# Altium schematic sheet ADI COG Click Shield_v101_Semitron.SchDoc("ADI COG Click Shield_v101_Semitron")
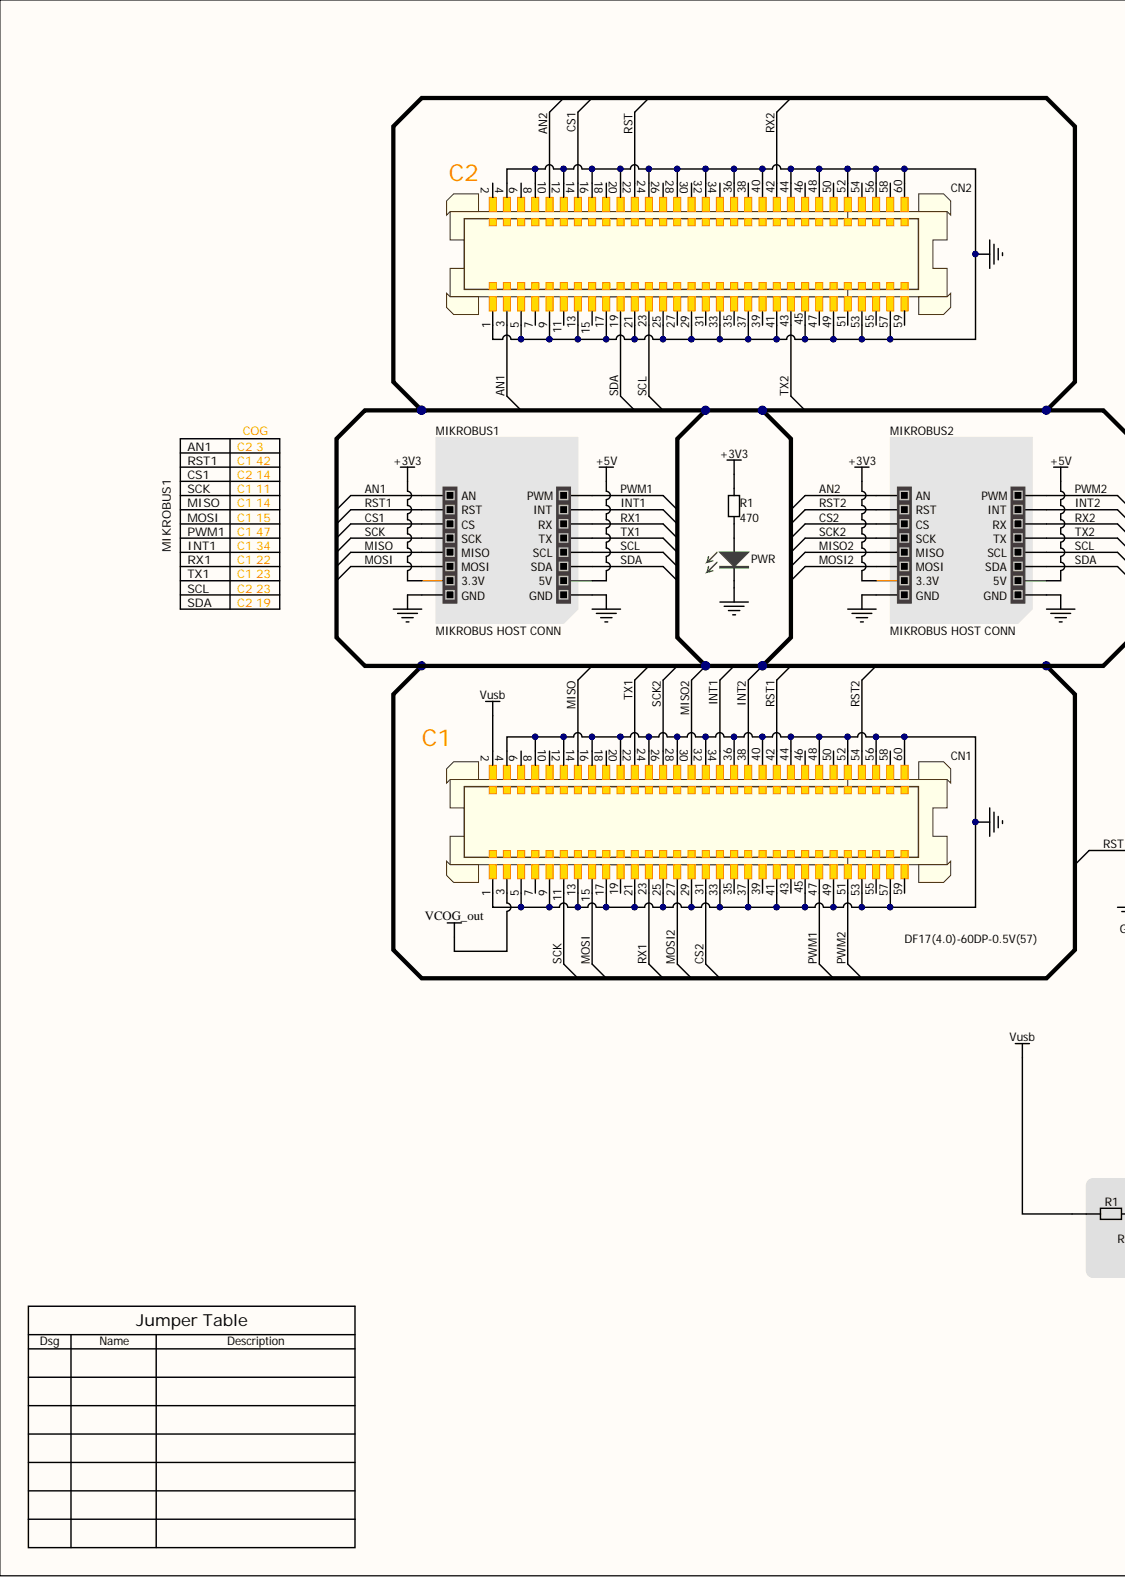
[diagram p0: ADI COG Click Shield_v101_Semitron.SchDoc, left]
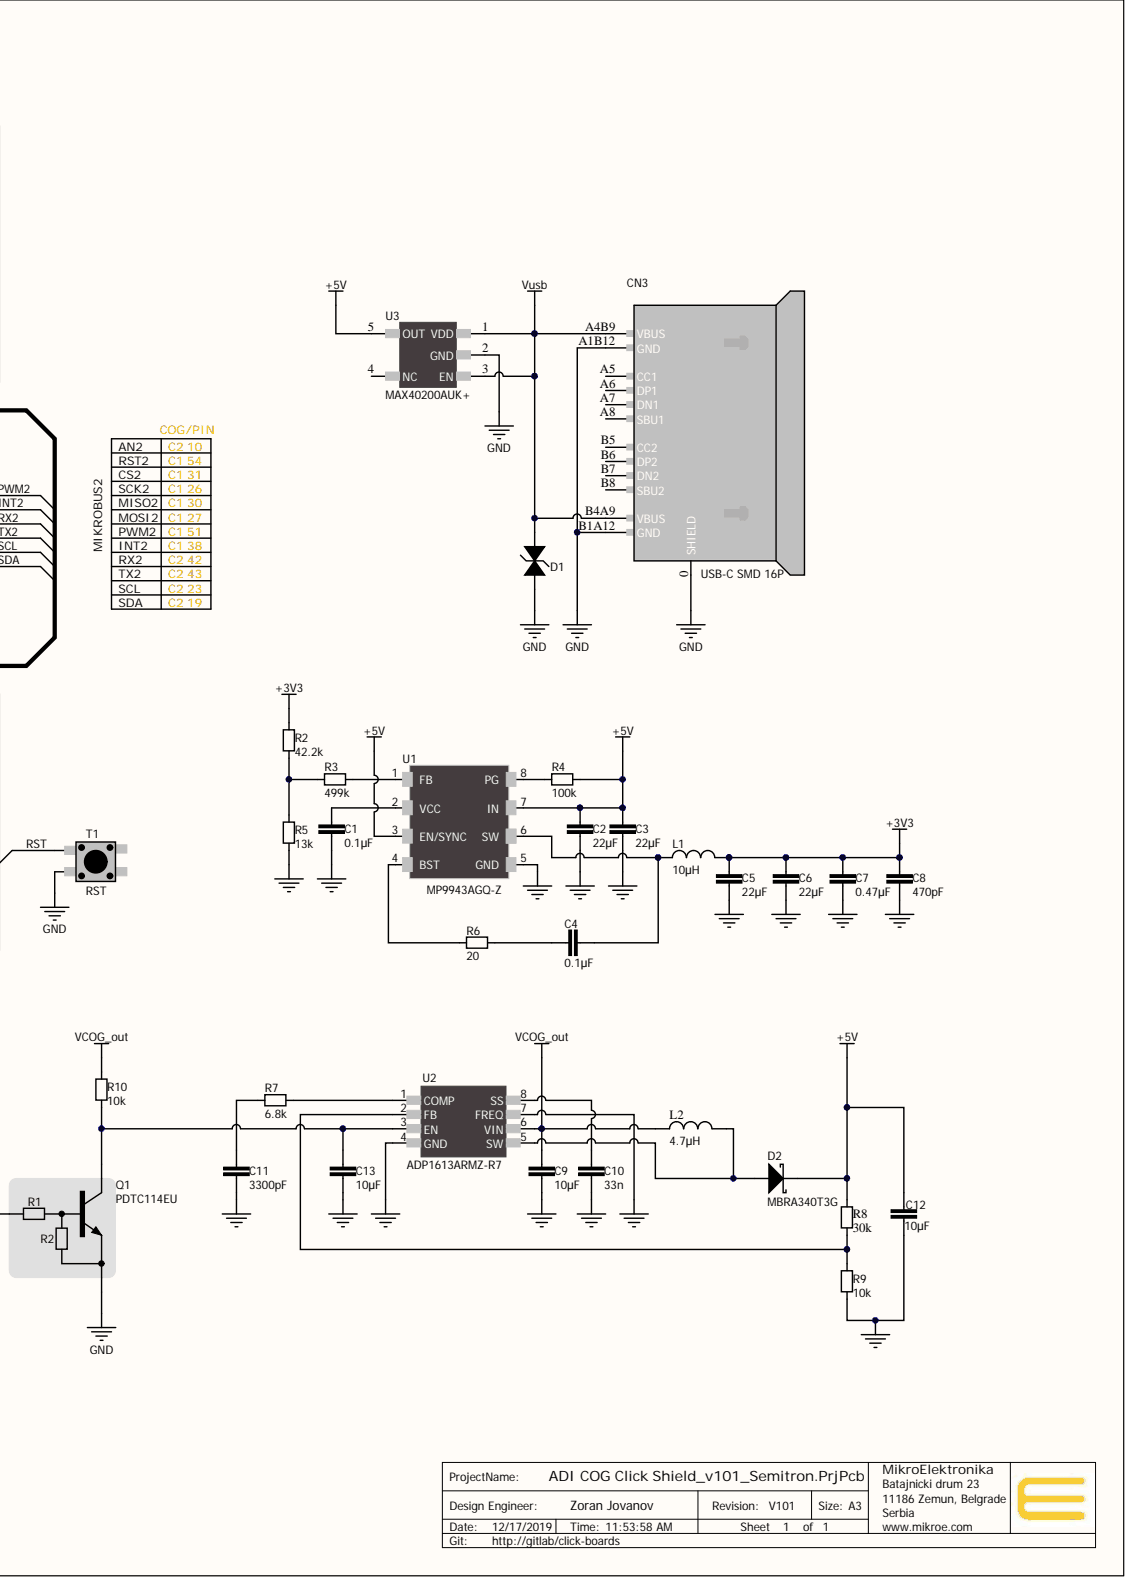
[diagram p1: ADI COG Click Shield_v101_Semitron.SchDoc, right]

## Parts
C1  0.1µF  [CAPC1005X55N-0402-NoOverlay]
C2  22µF  [CAPC2013X100N-0805-NoOverlay]
C3  22µF  [CAPC2013X100N-0805-NoOverlay]
C4  0.1µF  [CAPC1005X55N-0402-NoOverlay]
C5  22µF  [CAPC2013X100N-0805-NoOverlay]
C6  22µF  [CAPC2013X100N-0805-NoOverlay]
C7  0.47µF  [CAPC2013X100N-0805-NoOverlay]
C8  470pF  [CAPC2013X100N-0805-NoOverlay]
C9  10µF  [CAPC2013X100N-0805-NoOverlay]
C10  33n  [CAPC1608X55N-0603-NoOverlay]
C11  3300pF  [CAPC1608X55N-0603-NoOverlay]
C12  10µF  [CAPC2013X100N-0805-NoOverlay]
C13  10µF  [CAPC1608X55N-0603-NoOverlay]
CN1  DF17(4.0)-60DP-0.5V(57)  [PCBComponent_1]
CN2  DF17(4.0)-60DP-0.5V(57)  [PCBComponent_1]
CN3  USB-C SMD 16P  Assembly: SMD  [USB-C SMD 16P-NoOverlay]
D1  CPDUC5V0R-HF  [DIOC1508X55NP-0603-NoOverlay]  (value not drawn)
D2  MBRA340T3G  [DIODFN430X400X230-2N]
L1  10µH  [IND7.2X6.65X3-NoOverlay]
L2  4.7µH  [IND_HCM0703-NoOverlay]
LD1  PWR  [DIOGREENC2013X120N-0805-NoOverlay]
MIKROBUS1  MIKROBUS HOST CONN  [MIKROBUS HOST SMD 8GEN new V3]  (no BOM)
MIKROBUS2  MIKROBUS HOST CONN  [MIKROBUS HOST SMD 8GEN new V3]  (no BOM)
Q1  PDTC114EU  [SOT65P210X100-3N-NoOverlay]
R1  470  [RESC1508X55N-0603-NOOVERLAY]
R2  42.2k  [RESC1005X40N-0402-NOOVERLAY]
R3  499k  [RESC1005X40N-0402-NOOVERLAY]
R4  100k  [RESC1005X40N-0402-NOOVERLAY]
R5  13k  [RESC1005X40N-0402-NOOVERLAY]
R6  20  [RESC1005X40N-0402-NOOVERLAY]
R7  6.8k  [RESC1508X55N-0603-NOOVERLAY]
R8  30k  [RESC1508X55N-0603-NOOVERLAY]
R9  10k  [RESC1508X55N-0603-NOOVERLAY]
R10  10k  [RESC1508X55N-0603-NOOVERLAY]
T1  RST  [KMR221NG LFS-NoOverlay]
U1  MP9943AGQ-Z  [SON65P300X300X100-8N-VDFN-8-NoOverlay]
U2  ADP1613ARMZ-R7  [MSOP8P65_300X490X110L60X31N - NoOverlay]
U3  MAX40200AUK+  [SOT95P280X145-5L30N-SOT23-5-NoOverlay]

## Nets
+3V3: C5.2 C6.2 C7.2 C8.2 L1.2 MIKROBUS1.7(3.3V) MIKROBUS2.7(3.3V) R1.2 R2.2
+5V: C2.1 C3.2 C12.2 D2.1 MIKROBUS1.10(5V) MIKROBUS2.10(5V) R4.2 R8.2 U1.3(EN/SYNC) U1.7(IN) U3.5(OUT)
GND: C1.2 C2.2 C3.1 C5.1 C6.1 C7.1 C8.1 C9.2 C10.2 C11.1 C12.1 C13.1 CN1.1 CN1.4 CN1.5 CN1.8 CN1.9 CN1.12 CN1.13 CN1.16 CN1.17 CN1.20 CN1.21 CN1.24 CN1.25 CN1.28 CN1.29 CN1.32 CN1.33 CN1.36 CN1.37 CN1.40 CN1.41 CN1.44 CN1.45 CN1.48 CN1.49 CN1.52 CN1.53 CN1.56 CN1.57 CN1.60 CN2.1 CN2.4 CN2.5 CN2.8 CN2.9 CN2.12 CN2.13 CN2.16 CN2.17 CN2.20 CN2.21 CN2.24 CN2.25 CN2.28 CN2.29 CN2.32 CN2.33 CN2.36 CN2.37 CN2.40 CN2.41 CN2.44 CN2.45 CN2.48 CN2.49 CN2.52 CN2.53 CN2.56 CN2.57 CN2.60 CN3.0(SHIELD) CN3.A1B12(GND) CN3.B1A12(GND) D1.2 LD1.1 MIKROBUS1.8(GND) MIKROBUS1.9(GND) MIKROBUS2.8(GND) MIKROBUS2.9(GND) Q1.2 R5.1 R9.1 T1.1 U1.5(GND) U2.4(GND) U2.7(FREQ) U3.2(GND)
VCOG_out: C9.1 CN1.3 L2.1 R10.2 U2.6(VIN)
Vusb: CN1.2 CN3.A4B9(VBUS) CN3.B4A9(VBUS) D1.1 Q1.1 U3.1(VDD) U3.3(EN)
AN1: CN2.3 MIKROBUS1.1(AN)
AN2: CN2.10 MIKROBUS2.1(AN)
CS1: CN2.14 MIKROBUS1.3(CS)
CS2: CN1.31 MIKROBUS2.3(CS)
INT1: CN1.34 MIKROBUS1.15(INT)
INT2: CN1.38 MIKROBUS2.15(INT)
MISO: CN1.14 MIKROBUS1.5(MISO)
MISO2: CN1.30 MIKROBUS2.5(MISO)
MOSI: CN1.15 MIKROBUS1.6(MOSI)
MOSI2: CN1.27 MIKROBUS2.6(MOSI)
PWM1: CN1.47 MIKROBUS1.16(PWM)
PWM2: CN1.51 MIKROBUS2.16(PWM)
RST: CN2.22 T1.2
RST1: CN1.42 MIKROBUS1.2(RST)
RST2: CN1.54 MIKROBUS2.2(RST)
RX1: CN1.23 MIKROBUS1.14(RX)
RX2: CN2.42 MIKROBUS2.14(RX)
SCK: CN1.11 MIKROBUS1.4(SCK)
SCK2: CN1.26 MIKROBUS2.4(SCK)
SCL: CN2.23 MIKROBUS1.12(SCL) MIKROBUS2.12(SCL)
SDA: CN2.19 MIKROBUS1.11(SDA) MIKROBUS2.11(SDA)
TX1: CN1.22 MIKROBUS1.13(TX)
TX2: CN2.43 MIKROBUS2.13(TX)
NetC1_1: C1.1 U1.2(VCC)
NetC4_1: C4.1 L1.1 U1.6(SW)
NetC4_2: C4.2 R6.2
NetC10_1: C10.1 U2.8(SS)
NetC11_2: C11.2 R7.1
NetC13_2: C13.2 Q1.3 R10.1 U2.3(EN)
NetD2_2: D2.2 L2.2 U2.5(SW)
NetLD1_2: LD1.2 R1.1
NetR2_1: R2.1 R3.1 R5.2
NetR3_2: R3.2 U1.1(FB)
NetR4_1: R4.1 U1.8(PG)
NetR6_1: R6.1 U1.4(BST)
NetR7_2: R7.2 U2.1(COMP)
NetR8_1: R8.1 R9.2 U2.2(FB)
N00014:

Not connected: CN1 6 7 10 18 19 35 39 43 46 50 55 58 59; CN2 2 6 7 11 15 18 26 27 30 31 34 35 38 39 46 47 50 51 54 55 58 59; CN3 A5(CC1) A6(DP1) A7(DN1) A8(SBU1) B5(CC2) B6(DP2) B7(DN2) B8(SBU2); U3 4(NC)

## Library data of the parts
C1 C4 = 2911010025755: CAP CER 0.1UF 10V X5R 0402; Manufacturer Part Number: LMK105BJ104KV-F; Manufacturer: Taiyo Yuden; Tolerance: ±10%; Recommended Rating: Platinum; item_id: 3484; id: 63; Database Table Name: capacitors; Assembly: SMD; Voltage Rated: 10V; Temperature Coefficient: X5R; Part Type: Capacitors; Package Case: 0402 (1005 Metric); Library Name: ME-Altium Lib.SVNDbLib; Family: CERAMIC CAPACITOR; Datasheet URL: http://ds.yuden.co.jp/TYCOMPAS/ut/detail.do?productNo=LMK105BJ104KV-F&fileName=LMK105BJ104KV-F_SS&mode=specSheetDownload; Component Kind: Standard; Category: Capacitors; Capacitance: 0.1µF; Barcode: 2911010025755
C2 C3 C5 C6 = 0100000132753: CAP CER 22UF 16V X5R 0805; Manufacturer Part Number: C2012X5R1C226K125AC; Manufacturer: TDK Corporation; Tolerance: ±10%; item_id: 11393; id: 334; Database Table Name: capacitors; Assembly: SMD; Voltage Rated: 16V; Temperature Coefficient: X5R; Part Type: Capacitors; Package Case: 0805 (2012 Metric); Library Name: ME-Altium Lib.SVNDbLib; Family: CERAMIC CAPACITOR; Datasheet URL: https://product.tdk.com/info/en/catalog/datasheets/mlcc_commercial_general_en.pdf; Component Kind: Standard; Category: Capacitors; Capacitance: 22µF; Barcode: 0100000132753
C7 = 0100000015339: CAP CER 0.47UF 16V X7R 0805; Manufacturer Part Number: CL21B474KOFNNNG; Manufacturer: Samsung Electro-Mechanics America, Inc.; Tolerance: ±10%; Recommended Rating: Silver; item_id: 1963; id: 120; Database Table Name: capacitors; Assembly: SMD; Voltage Rated: 16V; Temperature Coefficient: X7R; Part Type: Capacitors; Package Case: 0805 (2012 Metric); Library Name: ME-Altium Lib.SVNDbLib; Family: CERAMIC CAPACITOR; Datasheet URL: http://www.samsungsem.com/kr/support/product-search/mlcc/CL21B474KOFNNNG.jsp; Component Kind: Standard; Category: Capacitors; Capacitance: 0.47µF; Barcode: 0100000015339
C8 = 0100000013397: CAP CER 470PF 50V X7R 0805; Manufacturer Part Number: CL21B471KBANNNC; Manufacturer: Samsung Electro-Mechanics America, Inc.; Tolerance: ±10%; item_id: 1757; id: 78; Database Table Name: capacitors; Assembly: SMD; Voltage Rated: 50V; Temperature Coefficient: X7R; Part Type: Capacitors; Package Case: 0805 (2012 Metric); Library Name: ME-Altium Lib.SVNDbLib; Family: CERAMIC CAPACITOR; Datasheet URL: http://www.samsungsem.com/kr/support/product-search/mlcc/CL21B471KBANNNC.jsp; Component Kind: Standard; Category: Capacitors; Capacitance: 470pF; Barcode: 0100000013397
C9 C12 = 0100000012963: CAP CER 10UF 25V X5R 0805; Manufacturer Part Number: GRM21BR61E106KA73L; Manufacturer: Murata Electronics North America; Tolerance: ±10%; item_id: 1714; id: 376; Database Table Name: capacitors; Assembly: SMD; Voltage Rated: 25V; Temperature Coefficient: X5R; Part Type: Capacitors; Package Case: 0805 (2012 Metric); Library Name: ME-Altium Lib.SVNDbLib; Family: CERAMIC CAPACITOR; Datasheet URL: http://www.murata.com/~/media/webrenewal/support/library/catalog/products/capacitor/mlcc/c02e.ashx; Component Kind: Standard; Category: Capacitors; Capacitance: 10µF; Barcode: 0100000012963
C10 = 2911010029463: Manufacturer Part Number: CC0603KRX7R9BB333; Manufacturer: Yageo; Voltage Rated: 50; Tolerance: 10%; Temperature Coefficient: X7R; Recommended Rating: Silver; item_id: 3855; id: 142; Database Table Name: capacitors; Assembly: SMD; Part Type: Capacitors; Package Case: 0603; Library Name: ME-Altium Lib.SVNDbLib; Family: CERAMIC CAPACITOR; Component Kind: Standard; Category: Capacitors; Capacitance: 33n; Barcode: 2911010029463
C11 = 2911010027094: CAP CER 3300PF 50V X7R 0603; Manufacturer Part Number: CL10B332KB8SFNC; Manufacturer: Samsung Electro-Mechanics America, Inc.; Tolerance: ±10%; Recommended Rating: Gold; item_id: 3618; id: 57; Database Table Name: capacitors; Assembly: SMD; Voltage Rated: 50V; Temperature Coefficient: X7R; Part Type: Capacitors; Package Case: 0603 (1608 Metric); Library Name: ME-Altium Lib.SVNDbLib; Family: CERAMIC CAPACITOR; Datasheet URL: http://www.samsungsem.com/kr/support/product-search/mlcc/CL10B332KB8SFNC.jsp; Component Kind: Standard; Category: Capacitors; Capacitance: 3300pF; Barcode: 2911010027094
C13 = 2911010042226: CAP CER 10UF 6.3V X5R 0603; Manufacturer Part Number: CL10A106MQ8NNNC; Manufacturer: Samsung Electro-Mechanics America, Inc.; Tolerance: ±20%; Recommended Rating: Platinum; item_id: 4902; id: 31; Database Table Name: capacitors; Assembly: SMD; Voltage Rated: 6.3V; Temperature Coefficient: X5R; Part Type: Capacitors; Package Case: 0603 (1608 Metric); Library Name: ME-Altium Lib.SVNDbLib; Family: CERAMIC CAPACITOR; Datasheet URL: http://www.samsungsem.com/kr/support/product-search/mlcc/CL10A106MQ8NNNL.jsp; Component Kind: Standard; Category: Capacitors; Capacitance: 10µF; Barcode: 2911010042226
CN1 CN2 = (no symbol name): CONN HDR 60POS SMD GOLD; Manufacturer: Hirose Electric Co Ltd; Pitch: 0.020" (0.50mm); Number of Positions: 60; item_id: 21259; id: 930; Connector Type: Header, Outer Shroud Contacts; Barcode: 3500000231215; Part Type: Connectors, Electromechanical; Number of Rows: 2; Mounting Type: Surface Mount; Manufacturer Part Number: DF17(4.0)-60DP-0.5V(57); Features: Board Guide, Solder Retention; Family: FEMALE SOCKET; Datasheet URL: https://www.hirose.com/product/download/?distributor=digikey&type=2d&lang=en&num=DF17(4.0)-60DP-0.5V(57); Contact Finish: Gold; Component Kind: Standard; Category: Connectors, Interconnects; Assembly: SMD; Library Name: COG.SchLib
CN3 = 3500000229724: CONN RCP USB3.1 TYPEC 24P SMD RA; Manufacturer: CHLink; Specifications: USB 3.1 (USB 3.1 Gen 2, Superspeed+); item_id: 21110; id: 923; Gender: Receptacle; Database Table Name: connectors_electromechanical; Part Type: Connectors, Electromechanical; Mounting Type: Surface Mount, Right Angle; Manufacturer Part Number: USB-C SMD 16P; Library Name: ME-Altium Lib.SVNDbLib; Features: -; Family: USB, DVI, HDMI; Datasheet URL: https://s3.us-west-2.amazonaws.com/share.mikroe.com/hardware/2019/Stevan%20Stepanov/TYPE-C%20%E6%AF%8D%E5%BA%A7%2016P%20%E4%BA%8C%E6%AC%A1%E6%88%90%E5%9E%8B%E5%9B%9B%E8%84%9A%E8%B4%B4%E7%89%87%20%E6%9C%89%E5%BC%B9-Model.pdf; Connector Type: USB - C; Component Kind: Standard; Category: Connectors, Interconnects; Barcode: 3500000229724
D1 = 3500000168597: TVS DIODE 5VWM 12VC 0603; Manufacturer: Comchip Technology; Voltage  Reverse Standoff: 5V (Max); Voltage Clamping Max Ipp: 12V; Voltage  Breakdown: 5.6V; item_id: 14994; id: 333; Database Table Name: discrete_semiconductors; Current Peak Pulse: 11A (8/20µs); Assembly: SMD; Part Type: Discrete Semiconductors; Package Case: 0603 (1608 Metric); Manufacturer Part Number: CPDUC5V0R-HF; Library Name: ME-Altium Lib.SVNDbLib; Family: TVS DIODE; Datasheet URL: http://media.digikey.com/pdf/Data%20Sheets/Comchip%20PDFs/CPDxx5V0R-HF.pdf; Component Kind: Standard; Category: Circuit Protection; Barcode: 3500000168597
D2 = 3500000184344: DIODE SCHOTTKY 40V 3A SMA; Manufacturer: ON Semiconductor; Speed: Fast Recovery =< 500ns, > 200mA (Io); item_id: 16571; id: 409; Database Table Name: discrete_semiconductors; Assembly: SMD; Voltage  DC Reverse: 40V; Part Type: Discrete Semiconductors; Package Case: DO-214AC, SMA; Manufacturer Part Number: MBRA340T3G; Library Name: ME-Altium Lib.SVNDbLib; Family: DIODE; Diode Type: Schottky; Datasheet URL: http://www.onsemi.com/pub/Collateral/MBRA340T3-D.PDF; Current  Average Rectified: 3A; Component Kind: Standard; Category: Discrete Semiconductor Products; Barcode: 3500000184344
L1 = 3500000150851: FIXED IND 10UH 4A 68 MOHM SMD; Manufacturer Part Number: ASPI-0630LR-100M-T15; Manufacturer: Abracon LLC; Tolerance: ±20%; item_id: 13221; id: 167; DC Resistance: 68 mOhm Max; Database Table Name: inductor_transf_filter_ferrite; Q at Freq: -; Part Type: Inductor, Transf, Filter, Ferrite; Package Case: Nonstandard; Library Name: ME-Altium Lib.SVNDbLib; Inductance: 10µH; Family: INDUCTOR; Datasheet URL: http://www.abracon.com/Magnetics/power/ASPI-0630LR.pdf; Current Rating: 4A; Component Kind: Standard; Category: Inductors, Coils, Chokes; Barcode: 3500000150851; Assembly: SMD
L2 = 2911010036492: FIXED IND 4.7UH 5.5A 40 MOHM SMD; Manufacturer Part Number: HCM0703-4R7-R; Manufacturer: Eaton Bussmann; Tolerance: ±20%; item_id: 4310; id: 38; DC Resistance: 40 mOhm Max; Database Table Name: inductor_transf_filter_ferrite; Q at Freq: -; Part Type: Inductor, Transf, Filter, Ferrite; Package Case: Nonstandard; Library Name: ME-Altium Lib.SVNDbLib; Inductance: 4.7µH; Family: INDUCTOR; Datasheet URL: http://www.cooperindustries.com/content/dam/public/bussmann/Electronics/Resources/product-datasheets/Bus_Elx_DS_4085_HCM0703_Series.pdf; Current Rating: 5.5A; Component Kind: Standard; Category: Inductors, Coils, Chokes; Barcode: 2911010036492; Assembly: SMD
LD1 = 2911010024338: LED GREEN CLEAR 0805 SMD; Manufacturer Part Number: APT2012SGC; Manufacturer: Kingbright; Wavelength: 568nm; Voltage Forward: 2.2V; Recommended Rating: Platinum; Lens Color: Colorless; item_id: 3342; id: 28; Database Table Name: optoelectronics; Part Type: Optoelectronics; Package Case: 0805 (2012 Metric); Library Name: ME-Altium Lib.SVNDbLib; Lens Transparency: Clear; Family: LED; Datasheet URL: http://www.kingbrightusa.com/images/catalog/SPEC/APT2012SGC.pdf; Component Kind: Standard; Color: Green; Category: Optoelectronics; Barcode: 2911010024338; Assembly: SMD
MIKROBUS1 MIKROBUS2 = 3500000164131: Manufacturer Part Number: DS1023-39-2*8B8XBSW; Manufacturer: CONNFLY; Number of Positions or Pins Grid: 16; item_id: 14548; id: 590; Database Table Name: connectors_electromechanical; Assembly: SMD; Type: Mikrobus; Part Type: Connectors, Electromechanical; Library Name: ME-Altium Lib.SVNDbLib; Family: SOCKET; Datasheet URL: http://ultran.ru/sites/default/files/catalog/soediniteli/brend/datasheets/ds1023-39.pdf; Barcode: 3500000164131
Q1 = 2911010027308: TRANS PREBIAS NPN 200MW SOT323; Manufacturer Part Number: PDTC114EU,115; Manufacturer: NXP Semiconductors; Voltage Collector Emitter Breakdown Max: 50V; Vce Saturation: 150mV @ 500µA, 10mA; Transistor Type: NPN - Pre-Biased; item_id: 3639; id: 40; Database Table Name: discrete_semiconductors; Current  Collector: 100mA; Assembly: SMD; Power Max: 200mW; Part Type: Discrete Semiconductors; Package Case: SC-70, SOT-323; Library Name: ME-Altium Lib.SVNDbLib; Family: TRANSISTOR; Datasheet URL: http://www.nxp.com/documents/data_sheet/PDTC114E_SER.pdf; Component Kind: Standard; Category: Discrete Semiconductor Products; Barcode: 2911010027308
R1 = 0100000013533: RES SMD 470 OHM 1% 1/10W 0603; Manufacturer Part Number: RC0603FR-07470RL; Manufacturer: Yageo; Temperature Coefficient: ±100ppm/°C; Recommended Rating: Gold; Power: 0.1W, 1/10W; item_id: 1771; id: 109; Database Table Name: resistors; Tolerance: ±1%; Resistance: 470; Part Type: Resistors; Package Case: 0603 (1608 Metric); Library Name: ME-Altium Lib.SVNDbLib; Family: RESISTOR; Datasheet URL: http://www.yageo.com/NewPortal/yageodocoutput?fileName=/pdf/R-Chip/PYu-RC_Group_51_RoHS_L_3.pdf; Component Kind: Standard; Category: Resistors; Barcode: 0100000013533; Assembly: SMD
R2 = 2911010036850: RES SMD 42.2K OHM 1% 1/16W 0402; Manufacturer Part Number: RC0402FR-0742K2L; Manufacturer: Yageo; Temperature Coefficient: ±100ppm/°C; Power: 0.063W, 1/16W; item_id: 4346; id: 63; Database Table Name: resistors; Tolerance: ±1%; Resistance: 42.2k; Part Type: Resistors; Package Case: 0402 (1005 Metric); Library Name: ME-Altium Lib.SVNDbLib; Family: RESISTOR; Datasheet URL: http://www.yageo.com/NewPortal/yageodocoutput?fileName=/pdf/R-Chip/PYu-RC_Group_51_RoHS_L_3.pdf; Component Kind: Standard; Category: Resistors; Barcode: 2911010036850; Assembly: SMD
R3 = 2911010036904: RES SMD 499K OHM 1% 1/16W 0402; Manufacturer Part Number: RC0402FR-07499KL; Manufacturer: Yageo; Temperature Coefficient: ±100ppm/°C; Power: 0.063W, 1/16W; item_id: 4351; id: 58; Database Table Name: resistors; Tolerance: ±1%; Resistance: 499k; Part Type: Resistors; Package Case: 0402 (1005 Metric); Library Name: ME-Altium Lib.SVNDbLib; Family: RESISTOR; Datasheet URL: http://www.yageo.com/NewPortal/yageodocoutput?fileName=/pdf/R-Chip/PYu-RC_Group_51_RoHS_L_3.pdf; Component Kind: Standard; Category: Resistors; Barcode: 2911010036904; Assembly: SMD
R4 = 2911010036867: RES SMD 100K OHM 1% 1/16W 0402; Manufacturer Part Number: RC0402FR-07100KL; Manufacturer: Yageo; Temperature Coefficient: ±100ppm/°C; Recommended Rating: Platinum; Power: 0.063W, 1/16W; item_id: 4347; id: 62; Database Table Name: resistors; Tolerance: ±1%; Resistance: 100k; Part Type: Resistors; Package Case: 0402 (1005 Metric); Library Name: ME-Altium Lib.SVNDbLib; Family: RESISTOR; Datasheet URL: http://www.yageo.com/NewPortal/yageodocoutput?fileName=/pdf/R-Chip/PYu-RC_Group_51_RoHS_L_3.pdf; Component Kind: Standard; Category: Resistors; Barcode: 2911010036867; Assembly: SMD
R5 = 3500000208927: RES SMD 13K OHM 5% 1/16W 0402; Manufacturer Part Number: RC0402JR-0713KL; Manufacturer: Yageo; Temperature Coefficient: ±100ppm/°C; Resistance: 13 kOhms; Power: 0.063W, 1/16W; item_id: 19028; id: 1106; Database Table Name: resistors; Tolerance: ±5%; Part Type: Resistors; Package Case: 0402 (1005 Metric); Library Name: ME-Altium Lib.SVNDbLib; Family: RESISTOR; Datasheet URL: http://www.yageo.com/documents/recent/PYu-RC_Group_51_RoHS_L_10.pdf; Component Kind: Standard; Category: Resistors; Barcode: 3500000208927; Assembly: SMD
R6 = 0100000109977: RES SMD 20 OHM 1% 1/16W 0402; Manufacturer Part Number: RC0402FR-0720RL; Manufacturer: Yageo; Temperature Coefficient: ±100ppm/°C; Power: 0.063W, 1/16W; item_id: 10111; id: 397; Database Table Name: resistors; Tolerance: ±1%; Resistance: 20; Part Type: Resistors; Package Case: 0402 (1005 Metric); Library Name: ME-Altium Lib.SVNDbLib; Family: RESISTOR; Datasheet URL: http://www.yageo.com/documents/recent/PYu-RC_Group_51_RoHS_L_04.pdf; Component Kind: Standard; Category: Resistors; Barcode: 0100000109977; Assembly: SMD
R7 = 0100000110485: RES SMD 6.8K OHM 0.1% 1/10W 0603; Manufacturer Part Number: ERA-3AEB682V; Manufacturer: Panasonic Electronic Components; Temperature Coefficient: ±25ppm/°C; Power: 0.1W, 1/10W; item_id: 10162; id: 403; Database Table Name: resistors; Tolerance: ±0.1%; Resistance: 6.8k; Part Type: Resistors; Package Case: 0603 (1608 Metric); Library Name: ME-Altium Lib.SVNDbLib; Family: RESISTOR; Datasheet URL: http://media.digikey.com/pdf/Data%20Sheets/Panasonic%20Electronic%20Components/ERA%201A,2A,3A,6A,8A.pdf; Component Kind: Standard; Category: Resistors; Barcode: 0100000110485; Assembly: SMD
R8 = 0100000072554: RES SMD 30K OHM 1% 1/10W 0603; Manufacturer Part Number: RMCF0603FT30K0; Manufacturer: Stackpole Electronics Inc.; Temperature Coefficient: ±100ppm/°C; Power: 0.1W, 1/10W; item_id: 6400; id: 10; Database Table Name: resistors; Tolerance: ±1%; Resistance: 30k; Part Type: Resistors; Package Case: 0603 (1608 Metric); Library Name: ME-Altium Lib.SVNDbLib; Family: RESISTOR; Datasheet URL: http://www.seielect.com/Catalog/SEI-RMCF_RMCP.pdf; Component Kind: Standard; Category: Resistors; Barcode: 0100000072554; Assembly: SMD
R9 R10 = 0100000013427: RES SMD 10K OHM 1% 1/10W 0603; Manufacturer Part Number: RC0603FR-0710KL; Manufacturer: Yageo; Temperature Coefficient: ±100ppm/°C; Recommended Rating: Platinum; Power: 0.1W, 1/10W; item_id: 1760; id: 193; Database Table Name: resistors; Tolerance: ±1%; Resistance: 10k; Part Type: Resistors; Package Case: 0603 (1608 Metric); Library Name: ME-Altium Lib.SVNDbLib; Family: RESISTOR; Datasheet URL: http://www.yageo.com/NewPortal/yageodocoutput?fileName=/pdf/R-Chip/PYu-RC_Group_51_RoHS_L_3.pdf; Component Kind: Standard; Category: Resistors; Barcode: 0100000013427; Assembly: SMD
T1 = 2911010042202: SWITCH TACTILE SPST-NO 0.05A 32V; Manufacturer Part Number: KMR221NG LFS; Manufacturer: C&K Components; item_id: 4900; id: 87; Database Table Name: connectors_electromechanical; Contact Rating Voltage: 0.05A @ 32VDC; Assembly: SMD; Part Type: Connectors, Electromechanical; Mounting Type: Surface Mount; Library Name: ME-Altium Lib.SVNDbLib; Features: -; Family: SWITCH TACTILE; Datasheet URL: http://www.ck-components.com/index.php?module=media&action=Display&cmpref=14414&lang=en&width=&height=&format=&alt=; Component Kind: Standard; Circuit: SPST-NO; Category: Switches; Barcode: 2911010042202
U1 = 3500000201539: HIGH EFFICIENCY 3A PEAK,36V,SYNC; Manufacturer: Monolithic Power Systems Inc.; Voltage  Input Min: 4V; Voltage  Input Max: 30V; Topology: Buck; Output Type: Adjustable; Output Configuration: Positive; Number of Outputs: 1; item_id: 18289; id: 2089; Function: Step-Down; Database Table Name: integrated_circuits; Assembly: SMD; Part Type: Integrated Circuits; Package Case: 8-VDFN; Manufacturer Part Number: MP9943AGQ-Z; Library Name: ME-Altium Lib.SVNDbLib; Family: Voltage Regulators - DC DC Switching Regulators; Datasheet URL: https://www.monolithicpower.com/pub/media/document/MP9943A_r1.1.pdf; Current  Output: 3A; Component Kind: Standard; Category: Integrated Circuits (ICs); Barcode: 3500000201539
U2 = 3500000227393: Manufacturer: Analog Devices Inc.; Voltage  Input Min: 2.5V; Voltage  Input Max: 5.5V; Topology: Boost, SEPIC; Output Type: Adjustable; Output Configuration: Positive; Number of Outputs: 1; item_id: 20877; id: 2576; Function: Step-Up, Step-Up/Step-Down; Database Table Name: integrated_circuits; Current  Output: 2A (Switch); Assembly: SMD; Part Type: Integrated Circuits; Package Case: 8-TSSOP, 8-MSOP (0.118", 3.00mm Width); Manufacturer Part Number: ADP1613ARMZ-R7; Library Name: ME-Altium Lib.SVNDbLib; Family: Voltage Regulators - DC DC Switching Regulators; Datasheet URL: https://www.analog.com/media/en/technical-documentation/data-sheets/ADP1612_1613.pdf; Component Kind: Standard; Barcode: 3500000227393
U3 = 3500000152664: IC DIODE CURRENT SWICTH SOT23-5; Manufacturer: Maxim Integrated; Voltage  Supply: 1.5 V ~ 5.5 V; item_id: 13402; Internal Switch s: Yes; id: 1398; FET Type: P-Channel; Database Table Name: integrated_circuits; Current  Supply: 7µA; Current Output Max: 1.2A; Assembly: SMD; Type: Source Selector Switch; Part Type: Integrated Circuits; Part Status: Active; Package Case: SC-74A, SOT-753; Manufacturer Part Number: MAX40200AUK+; Library Name: ME-Altium Lib.SVNDbLib; Family: PMIC - OR Controllers, Ideal Diodes; Datasheet URL: https://datasheets.maximintegrated.com/en/ds/MAX40200.pdf; Component Kind: Standard; Category: Integrated Circuits (ICs); Barcode: 3500000152664; Applications: Handheld/Mobile Devices
